# Altium schematic sheet Kinen Port.SchDoc
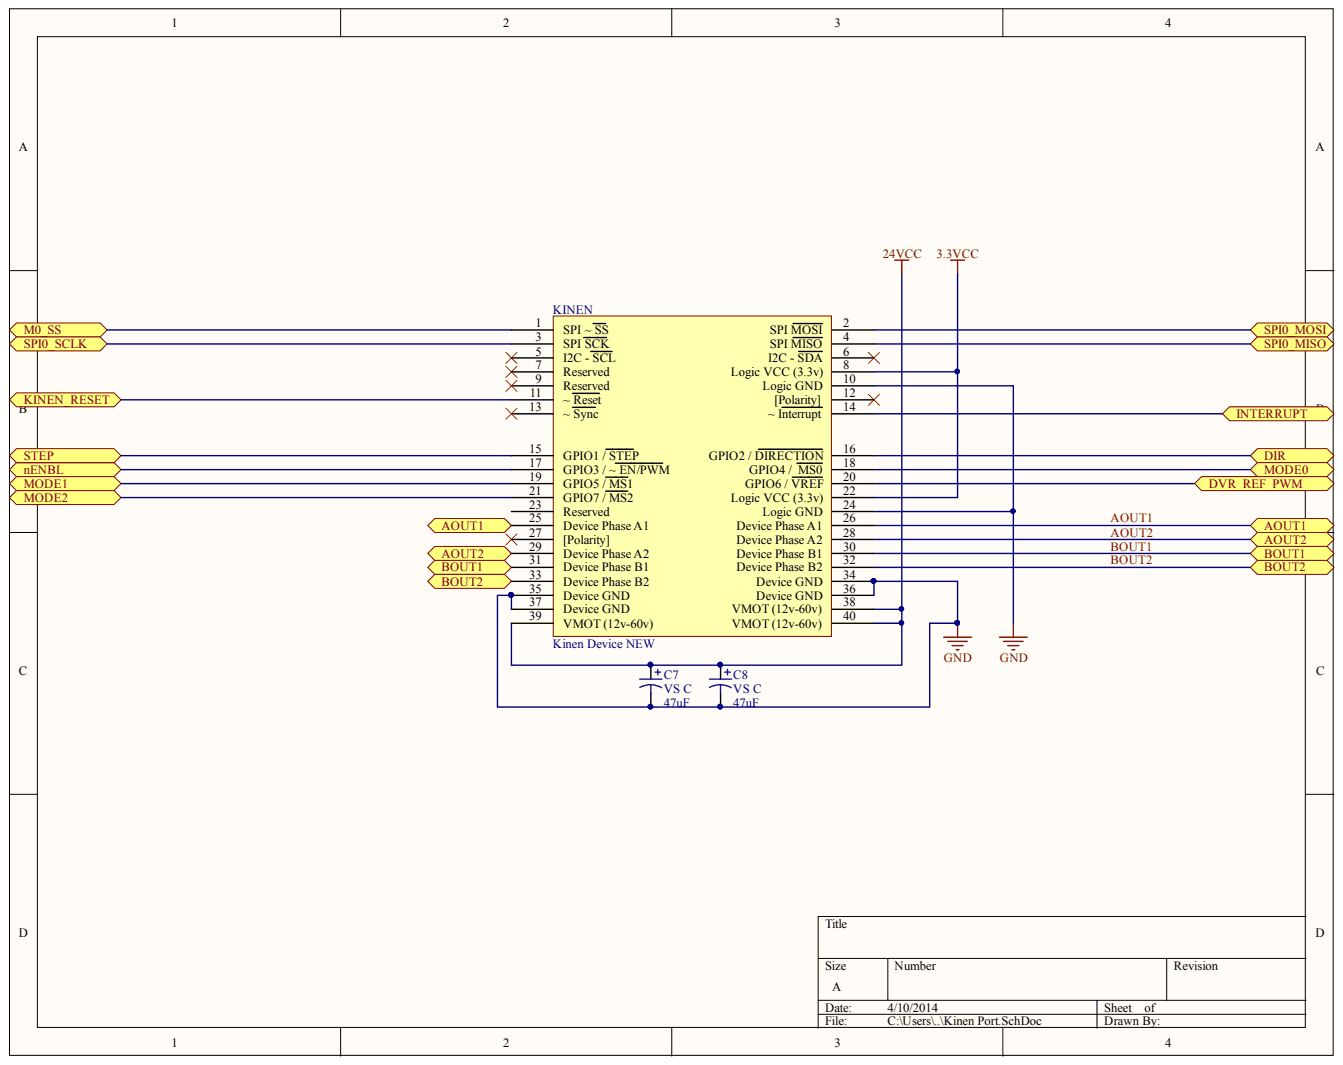
[diagram p0: Kinen Port.SchDoc]

## Parts
C7  VS C  value 47uF
C8  VS C  value 47uF
KINEN  Kinen Device NEW

## Ports
AOUT1, AOUT2, BOUT1, BOUT2, DIR, DVR_REF_PWM, INTERRUPT, KINEN_RESET, M0_SS, MODE0, MODE1, MODE2, NENBL, SPI0_MISO, SPI0_MOSI, SPI0_SCLK, STEP

## Nets
3.3VCC: KINEN.8(Logic_VCC_(3.3v)) KINEN.22(Logic_VCC_(3.3v))
24VCC: C7.1 C8.1 KINEN.38(VMOT_(12v-60v)) KINEN.39(VMOT_(12v-60v)) KINEN.40(VMOT_(12v-60v))
GND: C7.2 C8.2 KINEN.10(Logic_GND) KINEN.24(Logic_GND) KINEN.34(GND) KINEN.35 KINEN.36(GND) KINEN.37
AOUT1: KINEN.25 KINEN.26(Phase_A1) ; port AOUT1
AOUT2: KINEN.28(Phase_A2) KINEN.29 ; port AOUT2
BOUT1: KINEN.30(Phase_B1) KINEN.31 ; port BOUT1
BOUT2: KINEN.32(Phase_B2) KINEN.33 ; port BOUT2
DIR: KINEN.16(GPIO2_/_DIRECTION) ; port DIR
DVR_REF_PWM: KINEN.20(GPIO6_/_VREF) ; port DVR_REF_PWM
INTERRUPT: KINEN.14(~_Interrupt) ; port INTERRUPT
KINEN_RESET: KINEN.11(~_Reset) ; port KINEN_RESET
M0_SS: KINEN.1(SPI_~_SS) ; port M0_SS
MODE0: KINEN.18(MS0) ; port MODE0
MODE1: KINEN.19(GPIO5_/_MS1) ; port MODE1
MODE2: KINEN.21(GPIO7_/_MS2) ; port MODE2
SPI0_MISO: KINEN.4(SPI_MISO) ; port SPI0_MISO
SPI0_MOSI: KINEN.2(SPI_MOSI) ; port SPI0_MOSI
SPI0_SCLK: KINEN.3(SPI_SCK) ; port SPI0_SCLK
STEP: KINEN.15(GPIO1_/_STEP) ; port STEP
NetKINEN_17: KINEN.17(GPIO3_/_~_EN/PWM) ; port NENBL

Not connected: KINEN 5(I2C_-_SCL) 6(I2C_-_SDA) 7(Reserved) 9(Reserved) 12([Polarity]) 13(~_Sync) 23(Reserved) 27([Polarity])

## Library data of the parts
C7 C8 KINEN = (no symbol name)
  C7 C8: Published: 8-Jun-2000; LatestRevisionDate: 17-Jul-2002; LatestRevisionNote: Re-released for DXP Platform.; PackageReference: RB7.6-15; PackageDescription: Polarized Capacitor (Radial); 2 Leads; Publisher: Altium Limited
